# Altium schematic sheet Power_Supply.SchDoc(Power_Supply)
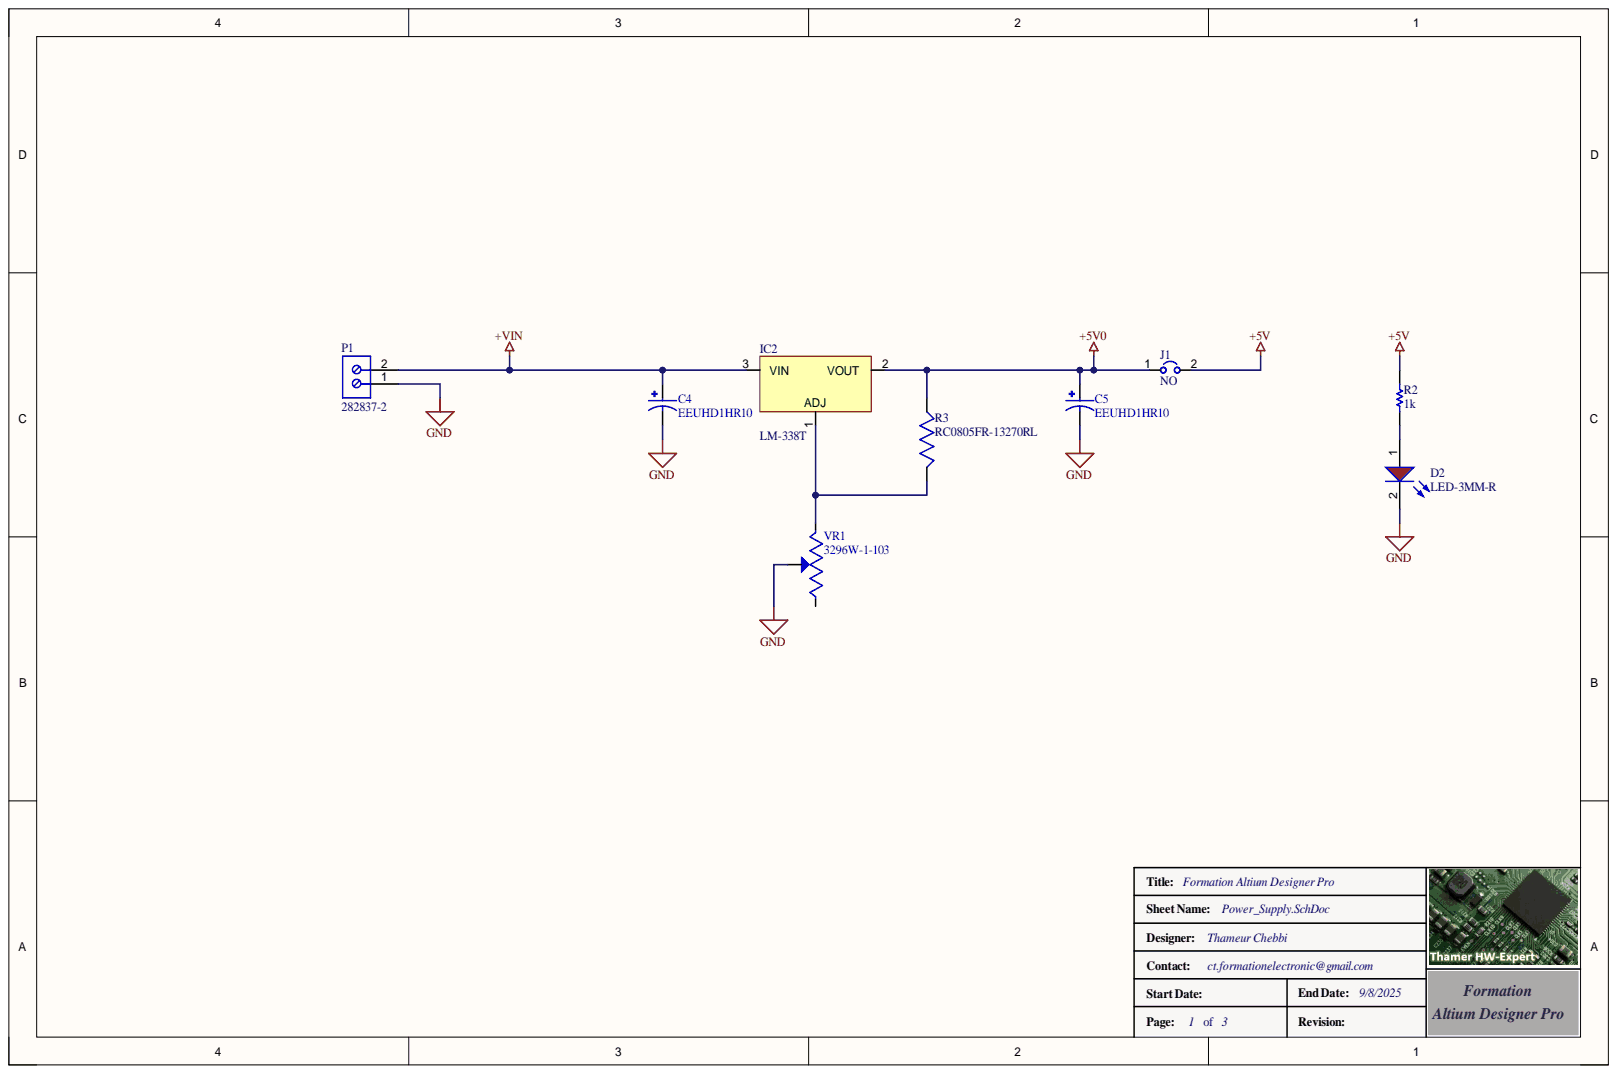
[diagram p0: Power_Supply.SchDoc]

## Parts
C4  EEUHD1HR10  [CAP_ALUM_5x11_RM2.5]
C5  EEUHD1HR10  [CAP_ALUM_5x11_RM2.5]
D2  LED-3MM-R  [LED-2.54MM-R]
IC2  LM-338T  [TO-220-3]
J1  NO  [Jumper]
P1  282837-2  [TE_282837-2]
R2  1k  [RESC2013X50X30LL20T20]
R3  RC0805FR-13270RL  [RESC2012X60N]
VR1  3296W-1-103  [TRIM_3296W-1-103]

## Nets
+5V: C5.1 IC2.2 J1.1 J1.2 R2.1 R3.2
+VIN: C4.1 IC2.3 P1.2
GND: C4.2 C5.2 D2.2 P1.1 VR1.2
NetD2_1: D2.1 R2.2
NetIC2_1: IC2.1 R3.1 VR1.3

Not connected: VR1 1

## Library data of the parts
C4 C5 = EEUHD1HR10: MF: {'manufacturer': u'Panasonic'}; Availability: Unavailable; Component Kind: Standard; Library Name: EEU-HD1HR10.SchLib; MP: EEUHD1HR10; Package: None; Price: None
IC2 = IC_LM_338T: Component Kind: Standard; Library Name: Symbol_Lib.SchLib
J1 = Jumper_NO: Component Kind: Standard; Library Name: Symbol_Lib.SchLib
P1 = 282837-2: TERM BLOCK 5.08MM 2POS SIDE ENTY; MF: {'manufacturer': u'TE Connectivity'}; Availability: Unavailable; Component Kind: Standard; Library Name: 282837-2.SchLib; MP: 282837-2; Package: None; Price: None
R2 = RES-0805-SMD: Component Kind: Standard; Value: 1k; Library Name: SCH_THA.SchLib
R3 = RC0805FR-13270RL: MF: {'manufacturer': u'Yageo Corporation'}; Availability: Unavailable; Component Kind: Standard; Library Name: RC0805FR13270RL.SchLib; MP: RC0805FR-13270RL; Package: None; Price: None
VR1 = 3296W-1-103: MF: {'manufacturer': u'Bourns'}; Availability: Unavailable; Component Kind: Standard; Library Name: 3296W-1-103.SchLib; MP: 3296W-1-103; Package: None; Price: None
D2 = LED-3MM-R: Component Kind: Standard; Library Name: SCH_THA.SchLib
